# Altium schematic sheet 3.SEPIC.SchDoc(3.SEPIC)
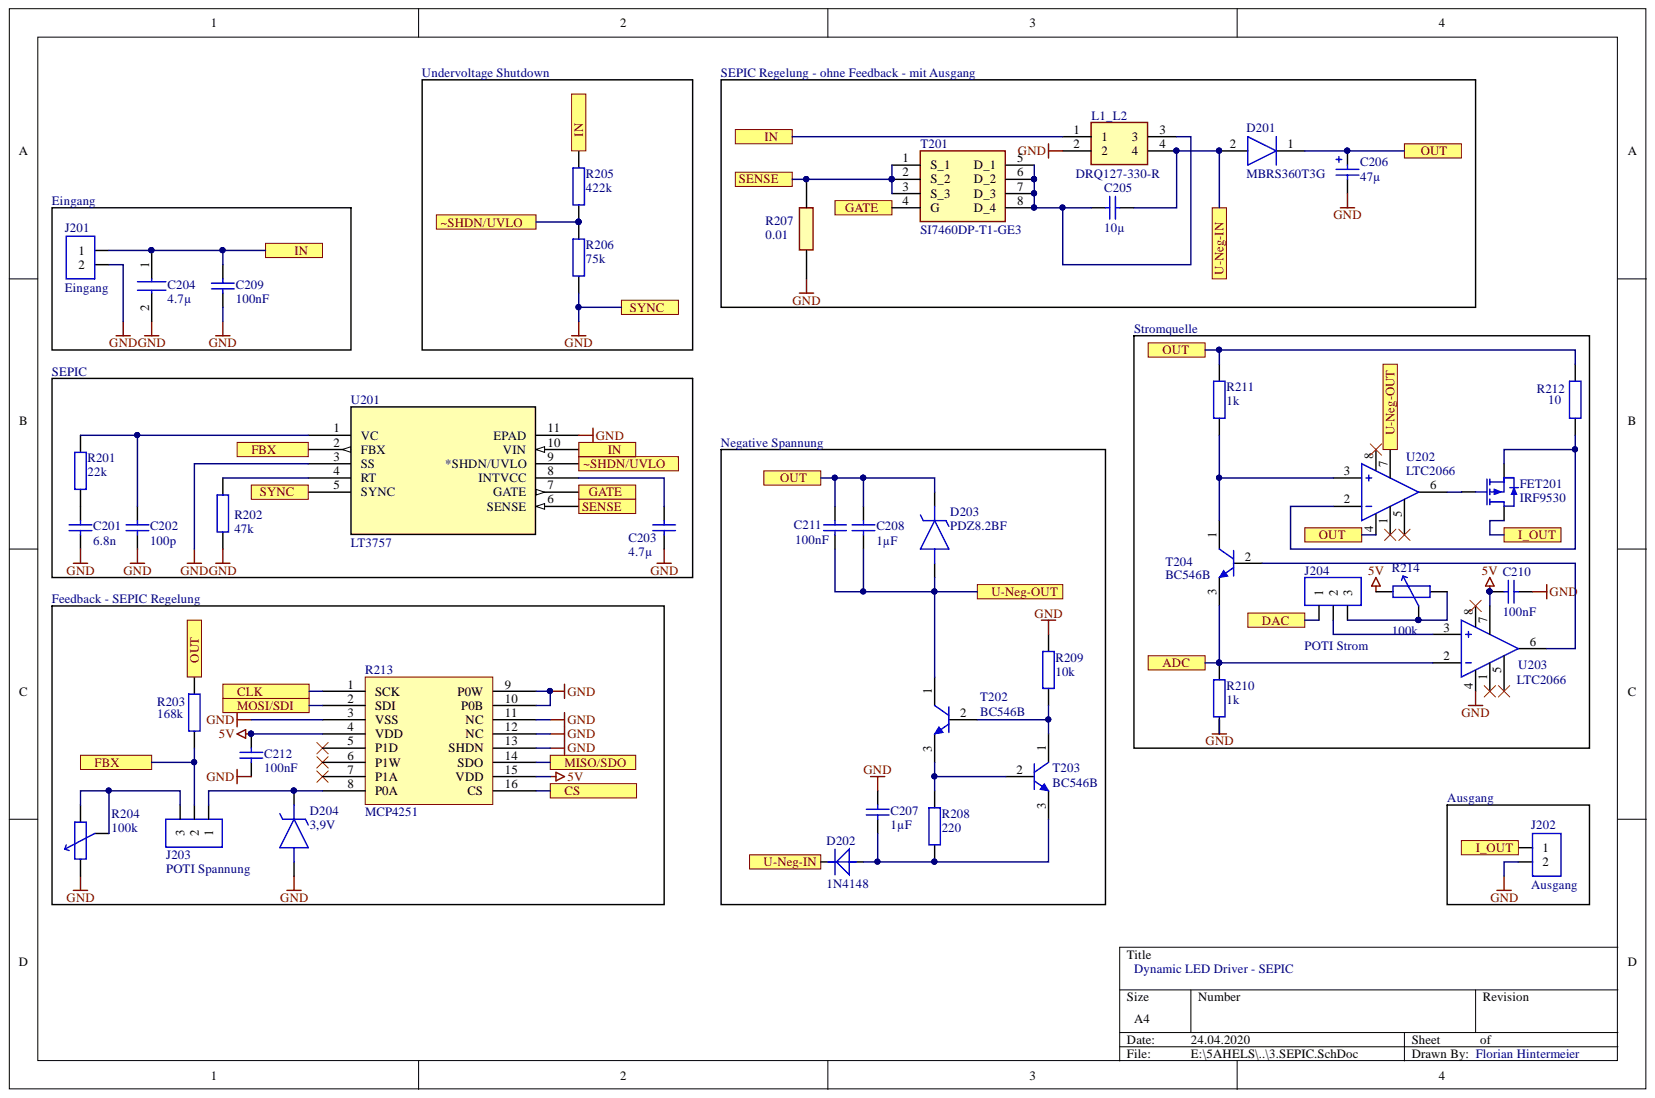
[diagram p0: 3.SEPIC.SchDoc]

## Parts
C201  6.8n  [0805]
C202  100p  [0805]
C203  4.7µ  [0805]
C204  4.7µ  [CAPC3216X180N]
C205  10µ  [0805]
C206  47µ  [RB-.1/.2]
C207  1µF  [0805]
C208  1µF  [0805]
C209  value 100nF  [C1206]  (hidden comment: Cap Semi)
C210  value 100nF  [C1206]  (hidden comment: Cap Semi)
C211  value 100nF  [C1206]  (hidden comment: Cap Semi)
C212  value 100nF  [C1206]  (hidden comment: Cap Semi)
D201  MBRS360T3G  [DIOM7958X256N]
D202  1N4148  [Diode-0.4]
D203  PDZ8.2BF  [SOD2512X110N]
D204  3,9V  [SOD2512X110N]
FET201  IRF9530  [TO-220H]
J201  Eingang  [SIP-2]
J202  Ausgang  [SIP-2]
J203  POTI Spannung  [Sip-3]
J204  POTI Strom  [Sip-3]
L1_L2  DRQ127-330-R  [INDM125125X800-4N]
R201  22k  [0805]
R202  47k  [0805]
R203  168k  [0805]
R204  100k  [Trimmer]
R205  422k  [0805]
R206  75k  [0805]
R207  0.01  [RESC6331X75N]
R208  220  [0805]
R209  10k  [0805]
R210  1k  [0805]
R211  1k  [0805]
R212  10  [0805]
R213  MCP4251  [MCP4251]
R214  100k  [Trimmer]
T201  SI7460DP-T1-GE3  [SI7141DPT1GE3]
T202  BC546B  [TO-92/EBC]
T203  BC546B  [TO-92/EBC]
T204  BC546B  [TO-92/EBC]
U201  LT3757  [DFN-10_DD-M]
U202  LTC2066  [SO-8]
U203  LTC2066  [SO-8]

## Ports
ADC, CLK, CS, DAC, FBX, GATE, I_OUT, IN, MISO/SDO, MOSI/SDI, OUT, SENSE, SYNC, U-Neg-IN, U-Neg-OUT, ~SHDN/UVLO

## Nets
5V: C210.2 C212.2 R213.4(VDD) R213.15(VDD) R214.2 U203.7
ADC: R210.2 T204.3 U203.2 ; port ADC
CLK: R213.1(SCK) ; port CLK
CS: R213.16(CS) ; port CS
DAC: J204.1 ; port DAC
FBX: J203.2 R203.1 U201.2(FBX) ; port FBX
GATE: T201.4(G) U201.7(GATE) ; port GATE
GND: C201.2 C202.2 C203.2 C204.2 C206.2 C207.1 C209.2 C210.1 C212.1 D204.2 J201.2 J202.2 L1_L2.2 R202.1 R204.2 R206.1 R207.1 R209.2 R210.1 R213.3(VSS) R213.9(P0W) R213.10(P0B) R213.11(NC) R213.12(NC) R213.13(SHDN) U201.3(SS) U201.5(SYNC) U201.11(EPAD) U203.4 ; port SYNC
I_OUT: FET201.3 J202.1 ; port I_OUT
IN: C204.1 C209.1 J201.1 L1_L2.1 R205.2 U201.10(VIN) ; port IN
MISO/SDO: R213.14(SDO) ; port MISO/SDO
MOSI/SDI: R213.2(SDI) ; port MOSI/SDI
OUT: C206.1 C208.1 C211.2 D201.1 D203.1 R203.2 R211.2 R212.2 U202.4 ; port OUT
SENSE: R207.2 T201.1(S_1) T201.2(S_2) T201.3(S_3) U201.6(SENSE) ; port SENSE
U-Neg-IN: C205.1 D201.2 D202.2 L1_L2.4 ; port U-Neg-IN
U-Neg-OUT: C208.2 C211.1 D203.2 T202.1 U202.7 ; port U-Neg-OUT
~SHDN/UVLO: R205.1 R206.2 U201.9(*SHDN/UVLO) ; port ~SHDN/UVLO
NetC201_1: C201.1 R201.1
NetC202_1: C202.1 R201.2 U201.1(VC)
NetC203_1: C203.1 U201.8(INTVCC)
NetC205_2: C205.2 L1_L2.3 T201.5(D_1) T201.6(D_2) T201.7(D_3) T201.8(D_4)
NetC207_2: C207.2 D202.1 R208.1 T203.3
NetD204_1: D204.1 J203.1 R213.8(P0A)
NetFET201_1: FET201.1 U202.6
NetFET201_2: FET201.2 R212.1 U202.2
NetJ203_3: J203.3 R204.1 R204.3
NetJ204_2: J204.2 U203.3
NetJ204_3: J204.3 R214.1 R214.3
NetR202_2: R202.2 U201.4(RT)
NetR208_2: R208.2 T202.3 T203.2
NetR209_1: R209.1 T202.2 T203.1
NetR211_1: R211.1 T204.1 U202.3
NetT204_2: T204.2 U203.6

Not connected: R213 5(P1D) 6(P1W) 7(P1A); U202 1 5 8; U203 1 5 8

## Library data of the parts
C201-C203 C205 C207 C208 = KO: Kondensator; Simulation: Model Name; Library Name: HTL-sym-2018-09.schlib; Component Kind: Standard
C204 = UMK316BJ475KL-T: Manufacturer_Name: TAIYO YUDEN; Mouser Price/Stock: https://www.mouser.com/Search/Refine.aspx?Keyword=963-UMK316BJ475KL-T; Mouser Part Number: 963-UMK316BJ475KL-T; Manufacturer_Part_Number: UMK316BJ475KL-T; Library Name: SamacSys.SchLib; Height: 1.8mm; Description: UMK316BJ475KL-T; Datasheet Link: https://mouser.componentsearchengine.com/Datasheets/5/UMK316BJ475KL-T.pdf; Component Kind: Standard
C206 = ELKO: Simulation: Model Name; Library Name: HTL-sym-2018-09.schlib; Description: Elko; Component Kind: Standard
C209-C212 = Cap Semi: Capacitor (Semiconductor SIM Model); Simulation: CAP; Signal Integrity: Cap Semi; Published: 8-Jun-2000; PackageReference: C1206; PackageDescription: Chip Capacitor; Library Name: Miscellaneous Devices.IntLib; LatestRevisionNote: IPC-7351 Footprint Added.; LatestRevisionDate: 29-May-2009; Component Kind: Standard; Publisher: Altium Limited
D201 = MBRS360T3G: Diode; Manufacturer_Name: ON Semiconductor; Manufacturer_Part_Number: MBRS360T3G; Library Name: SamacSys.SchLib; Height: 2.56mm; Datasheet Link: http://www.onsemi.com/pub/Collateral/MBRS360T3-D.PDF; Component Kind: Standard
D202 = DIODE: Description: Diode; Component Kind: Standard; Library Name: Sode-Sym.SchLib
D203 = PDZ8.2BF: Zener Diode; Manufacturer_Name: Nexperia; Mouser Price/Stock: https://www.mouser.com/Search/Refine.aspx?Keyword=771-PDZ82BF; Mouser Part Number: 771-PDZ82BF; Manufacturer_Part_Number: PDZ8.2BF; Library Name: SamacSys.SchLib; Height: 1.1mm; Datasheet Link: https://www.mouser.com/datasheet/2/916/PDZ-B_SER-1599722.pdf; Component Kind: Standard
D204 = Z-Diode: Zener Diode; Manufacturer_Part_Number: PDZ8.2BF; Manufacturer_Name: Nexperia; Mouser Price/Stock: https://www.mouser.com/Search/Refine.aspx?Keyword=771-PDZ82BF; Mouser Part Number: 771-PDZ82BF; Library Name: 6.Dynamic_LED_Driver.SchLib; Height: 1.1mm; Datasheet Link: https://www.mouser.com/datasheet/2/916/PDZ-B_SER-1599722.pdf; Component Kind: Standard
FET201 = IRF9530: P-MOSFET; Component Kind: Standard; Library Name: Gansch-Sym.SchLib
J201 J202 = CON2: Stecker; Library Name: HTL-sym-2018-09.schlib; Component Kind: Standard
J203 J204 = CON3: Stecker; Library Name: HTL-sym-2018-09.schlib; Component Kind: Standard
L1_L2 = DRQ127-330-R: Inductor; Manufacturer_Name: COILTRONICS; Allied_Number: 70037979; Manufacturer_Part_Number: DRQ127-330-R; Library Name: SamacSys.SchLib; Component Kind: Standard; Allied Price/Stock: https://www.alliedelec.com/coiltronics-drq127-330-r/70037979/
R201-R203 R205 R206 R208-R212 = RES: Widerstand; Simulation: Model Name; Library Name: HTL-sym-2018-09.schlib; Component Kind: Standard
R204 R214 = POT: Potentiometer; Value: 1k; Set Position: 0,5; Simulation: Model Name; Library Name: 6.Dynamic_LED_Driver.SchLib; Component Kind: Standard
R207 = CR2512-FX-1001ELF: Resistor; Manufacturer_Name: Bourns; Mouser Price/Stock: https://www.mouser.com/Search/Refine.aspx?Keyword=652-CR2512FX1001ELF; Mouser Part Number: 652-CR2512FX1001ELF; Manufacturer_Part_Number: CR2512-FX-1001ELF; Height: 0.75mm; Component Kind: Standard
T201 = SI7460DP-T1-GE3: MOSFET (N-Channel); Manufacturer_Name: Vishay; Manufacturer_Part_Number: SI7460DP-T1-GE3; Library Name: Dynamic_LED_Driver.IntLib; Datasheet Link: http://www.vishay.com/docs/72126/si7460dp.pdf; Component Kind: Standard
T202-T204 = BC546B: Amplifier Transistor NPN Silicon; Simulation: BC546B; Signal Integrity: BC546B; Published: 29-Sep-2000; PackageVersion: 1996; PackageReference: 29-04; PackageDescription: TO, Flat Index; 3 In-Line, Axial Leads; Body Dia. 4.8mm; Leads 0.48 x 0.5 mm (max); Library Name: HTL-sym-2018-09.schlib; LatestRevisionNote: Re-released for DXP Platform.; LatestRevisionDate: 17-Jul-2002; DatasheetVersion: 1991; ComponentLink2URL: http://www.onsemi.com/pub/Collateral/BC546-D.PDF; ComponentLink2Description: Datasheet; ComponentLink1URL: http://www.onsemi.com/site/products/landing?taxonomyID=194; ComponentLink1Description: Manufacturer Link; Component Kind: Standard; Publisher: Altium Limited
U201 = LT3757AEDDPBF: No Description Available; Manufacturer_Part_Number: lt3757aedd#pbf; Vendor: Linear Technology; Value: Value; Type: DEV; RefDes: RefDes; Library Name: LED_Driver_Library.SchLib; Component Kind: Standard; Copyright: Copyright (C) 2018 Accelerated Designs. All rights reserved
U202 U203 = LM6181: 100MHz OPV; Component Kind: Standard; Library Name: Gansch-Sym.SchLib
R213 = MCP4251: Library Name: 6.Dynamic_LED_Driver.SchLib; Component Kind: Standard
